# Altium schematic sheet S7CAS-PLEIADES-CARTE-MERE_P01_CNA.SchDoc
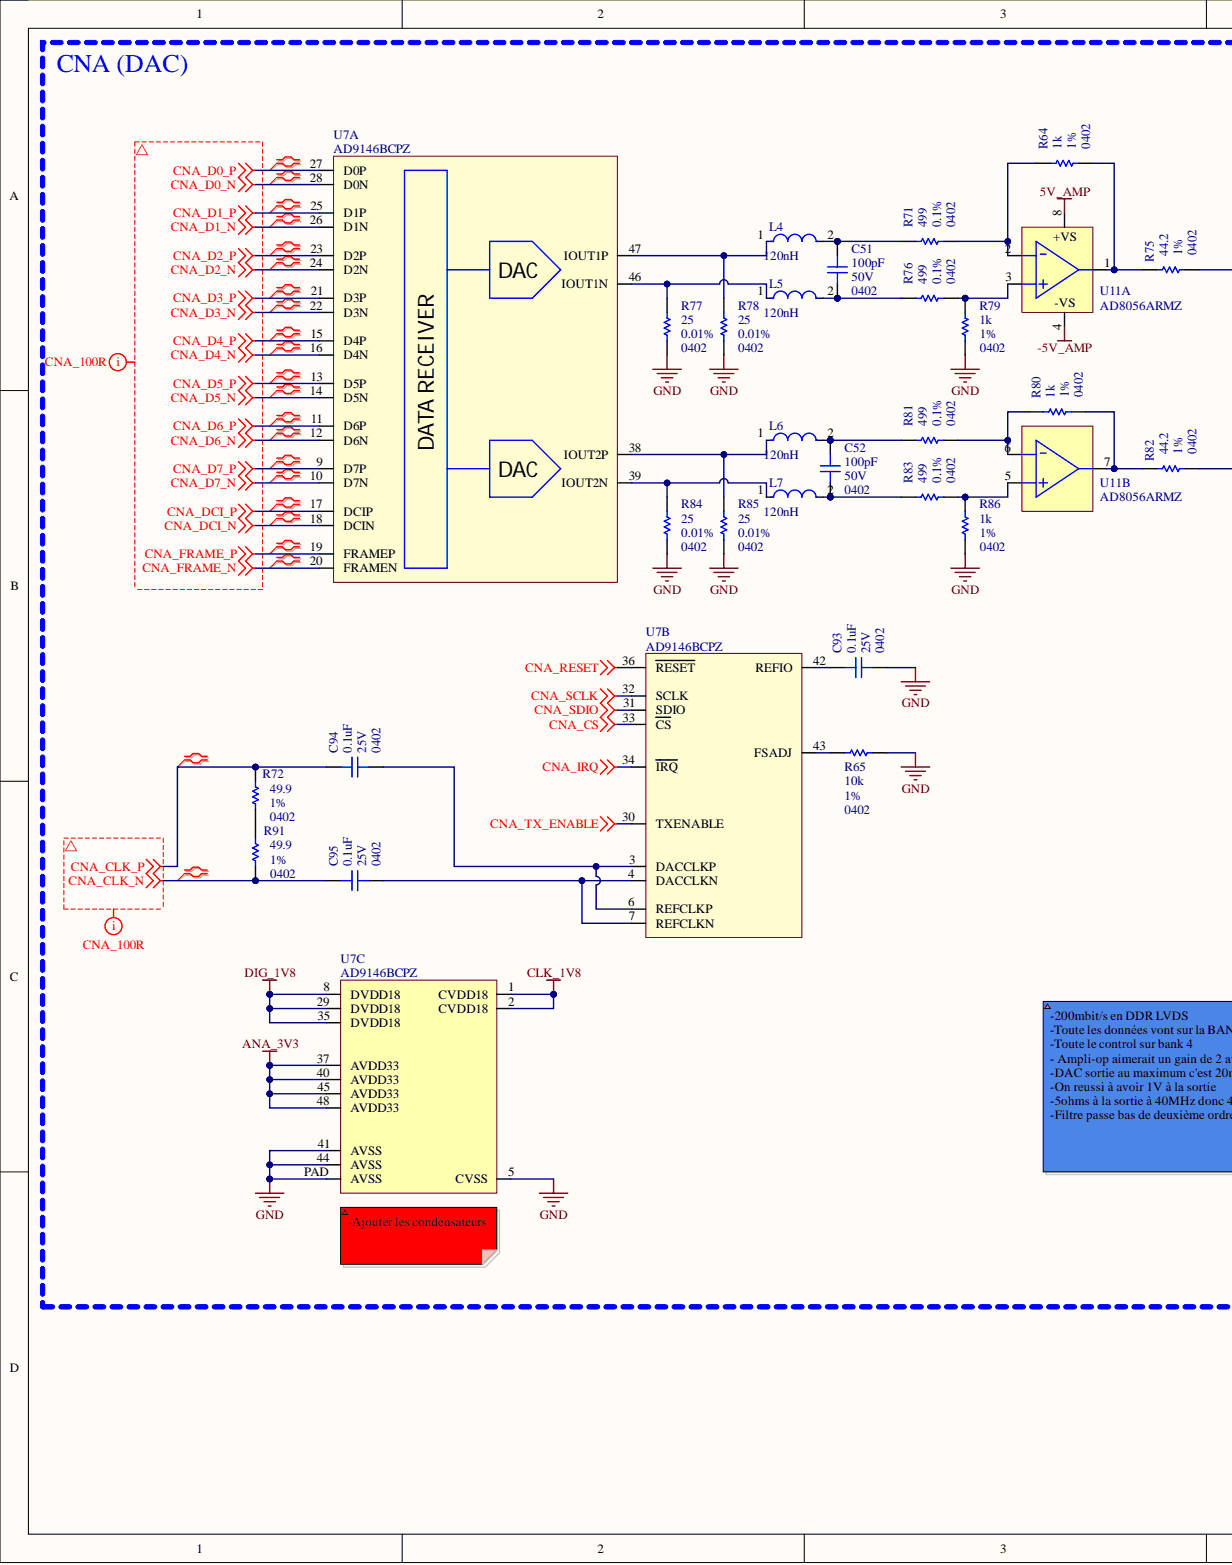
[diagram p0: S7CAS-PLEIADES-CARTE-MERE_P01_CNA.SchDoc, left]
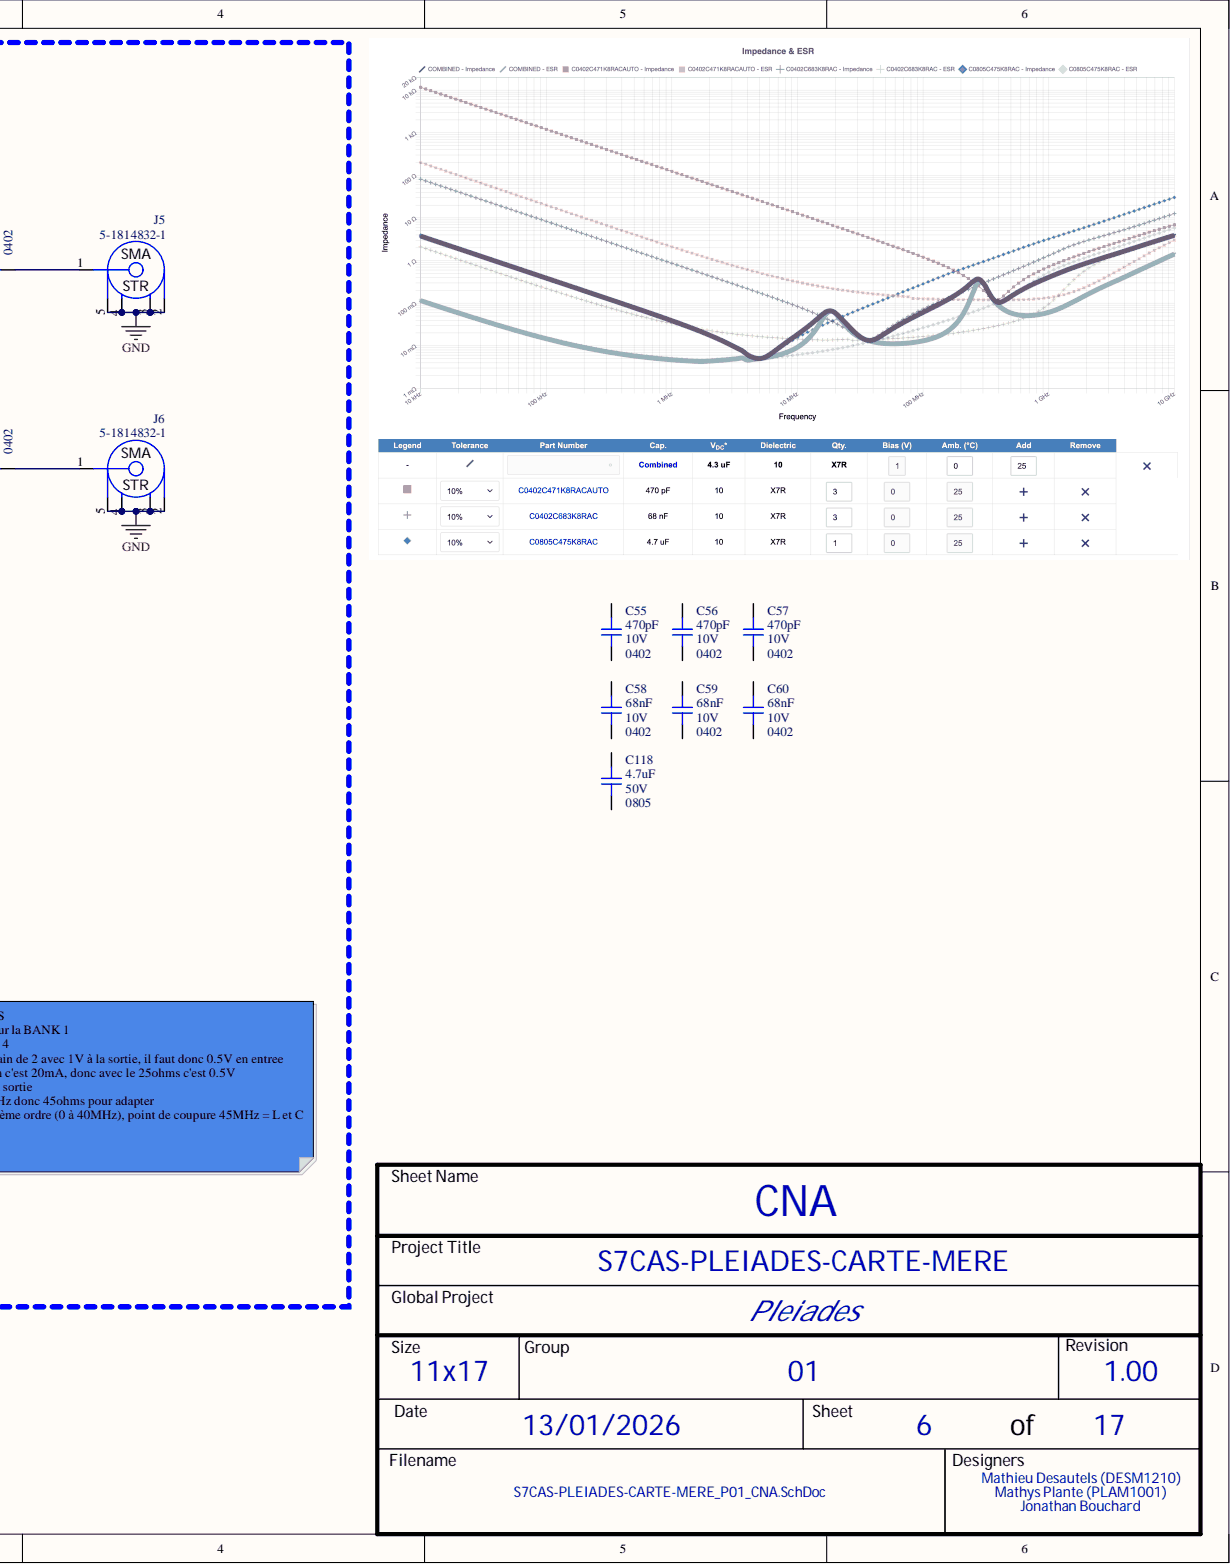
[diagram p1: S7CAS-PLEIADES-CARTE-MERE_P01_CNA.SchDoc, right]

## Parts
C51  value 100pF  Package: 0402  Voltage: 50V  [CAP_0402]  (hidden comment: CAP - 0402)
C52  value 100pF  Package: 0402  Voltage: 50V  [CAP_0402]  (hidden comment: CAP - 0402)
C55  value 470pF  Package: 0402  Voltage: 10V  [CAP_0402]  (hidden comment: CAP - 0402)
C56  value 470pF  Package: 0402  Voltage: 10V  [CAP_0402]  (hidden comment: CAP - 0402)
C57  value 470pF  Package: 0402  Voltage: 10V  [CAP_0402]  (hidden comment: CAP - 0402)
C58  value 68nF  Package: 0402  Voltage: 10V  [CAP_0402]  (hidden comment: CAP - 0402)
C59  value 68nF  Package: 0402  Voltage: 10V  [CAP_0402]  (hidden comment: CAP - 0402)
C60  value 68nF  Package: 0402  Voltage: 10V  [CAP_0402]  (hidden comment: CAP - 0402)
C93  value 0.1uF  Package: 0402  Voltage: 25V  [CAP_0402]  (hidden comment: CAP - 0402)
C94  value 0.1uF  Package: 0402  Voltage: 25V  [CAP_0402]  (hidden comment: CAP - 0402)
C95  value 0.1uF  Package: 0402  Voltage: 25V  [CAP_0402]  (hidden comment: CAP - 0402)
C118  value 4.7uF  Package: 0805  Voltage: 50V  [CAP_0805]  (hidden comment: CAP - 0805)
J5  5-1814832-1  [CONN_SMA_5-1814832-1]
J6  5-1814832-1  [CONN_SMA_5-1814832-1]
L4  Inductance: 120nH  [FP-LQH5BPB_T0-MFG]  (hidden comment: LQH5BPN1R2NT0L)
L5  Inductance: 120nH  [FP-LQH5BPB_T0-MFG]  (hidden comment: LQH5BPN1R2NT0L)
L6  Inductance: 120nH  [FP-LQH5BPB_T0-MFG]  (hidden comment: LQH5BPN1R2NT0L)
L7  Inductance: 120nH  [FP-LQH5BPB_T0-MFG]  (hidden comment: LQH5BPN1R2NT0L)
R64  value 1k  Package: 0402  Precision: 1%  [RES_0402]  (hidden comment: RES - 0402)
R65  value 10k  Precision: 1%  [RES_0402]  (hidden comment: RES - 0402)
R71  value 499  Package: 0402  Precision: 0.1%  [RES_0402]  (hidden comment: RES - 0402)
R72  value 49.9  Precision: 1%  [RES_0402]  (hidden comment: RES - 0402)
R75  value 44.2  Package: 0402  Precision: 1%  [RES_0402]  (hidden comment: RES - 0402)
R76  value 499  Package: 0402  Precision: 0.1%  [RES_0402]  (hidden comment: RES - 0402)
R77  value 25  Package: 0402  [RES_0402]  (hidden comment: RES - 0402)
R78  value 25  Package: 0402  Precision: 0.01%  [RES_0402]  (hidden comment: RES - 0402)
R79  value 1k  Package: 0402  Precision: 1%  [RES_0402]  (hidden comment: RES - 0402)
R80  value 1k  Package: 0402  Precision: 1%  [RES_0402]  (hidden comment: RES - 0402)
R81  value 499  Package: 0402  Precision: 0.1%  [RES_0402]  (hidden comment: RES - 0402)
R82  value 44.2  Package: 0402  Precision: 1%  [RES_0402]  (hidden comment: RES - 0402)
R83  value 499  Package: 0402  Precision: 0.1%  [RES_0402]  (hidden comment: RES - 0402)
R84  value 25  Package: 0402  [RES_0402]  (hidden comment: RES - 0402)
R85  value 25  Package: 0402  Precision: 0.01%  [RES_0402]  (hidden comment: RES - 0402)
R86  value 1k  Package: 0402  Precision: 1%  [RES_0402]  (hidden comment: RES - 0402)
R91  value 49.9  Package: 0402  Precision: 1%  [RES_0402]  (hidden comment: RES - 0402)
U7  AD9146BCPZ  [DAC_AD9146BCPZ]  (parts A,B,C)
U11  AD8056ARMZ  [OPAMP_AD8056ARMZ]  (parts A,B)

## Nets
5V_AMP: U11.8
-5V_AMP: U11.4
ANA_3V3: U7.37(AVDD33) U7.40(AVDD33) U7.45(AVDD33) U7.48(AVDD33)
CLK_1V8: U7.1(CVDD18) U7.2(CVDD18)
CNA_CLK_N: C95.2 R91.1
CNA_CLK_P: C94.2 R72.2
CNA_CS: U7.33(CS)
CNA_D0_N: U7.28(D0N)
CNA_D0_P: U7.27(D0P)
CNA_D1_N: U7.26(D1N)
CNA_D1_P: U7.25(D1P)
CNA_D2_N: U7.24(D2N)
CNA_D2_P: U7.23(D2P)
CNA_D3_N: U7.22(D3N)
CNA_D3_P: U7.21(D3P)
CNA_D4_N: U7.16(D4N)
CNA_D4_P: U7.15(D4P)
CNA_D5_N: U7.14(D5N)
CNA_D5_P: U7.13(D5P)
CNA_D6_N: U7.12(D6N)
CNA_D6_P: U7.11(D6P)
CNA_D7_N: U7.10(D7N)
CNA_D7_P: U7.9(D7P)
CNA_DCI_N: U7.18(DCIN)
CNA_DCI_P: U7.17(DCIP)
CNA_FRAME_N: U7.20(FRAMEN)
CNA_FRAME_P: U7.19(FRAMEP)
CNA_IRQ: U7.34(IRQ)
CNA_RESET: U7.36(RESET)
CNA_SCLK: U7.32(SCLK)
CNA_SDIO: U7.31(SDIO)
CNA_TX_ENABLE: U7.30(TXENABLE)
DIG_1V8: U7.8(DVDD18) U7.29(DVDD18) U7.35(DVDD18)
GND: C93.1 J5.2 J5.3 J5.4 J5.5 J6.2 J6.3 J6.4 J6.5 R65.2 R77.1 R78.1 R79.1 R84.1 R85.1 R86.1 U7.5(CVSS) U7.41(AVSS) U7.44(AVSS) U7.PAD(AVSS)
NetC51_1: C51.1 L5.2 R76.2
NetC51_2: C51.2 L4.2 R71.2
NetC52_1: C52.1 L7.2 R83.2
NetC52_2: C52.2 L6.2 R81.2
NetC93_2: C93.2 U7.42(REFIO)
NetC94_1: C94.1 U7.3(DACCLKP) U7.6(REFCLKP)
NetC95_1: C95.1 U7.4(DACCLKN) U7.7(REFCLKN)
NetJ5_1: J5.1 R75.1
NetJ6_1: J6.1 R82.1
NetL4_1: L4.1 R78.2 U7.47(IOUT1P)
NetL5_1: L5.1 R77.2 U7.46(IOUT1N)
NetL6_1: L6.1 R85.2 U7.38(IOUT2P)
NetL7_1: L7.1 R84.2 U7.39(IOUT2N)
NetR64_1: R64.1 R75.2 U11.1
NetR64_2: R64.2 R71.1 U11.2
NetR65_1: R65.1 U7.43(FSADJ)
NetR72_1: R72.1 R91.2
NetR76_1: R76.1 R79.2 U11.3
NetR80_1: R80.1 R82.2 U11.7
NetR80_2: R80.2 R81.1 U11.6
NetR83_1: R83.1 R86.2 U11.5

Not connected: C55 1 2; C56 1 2; C57 1 2; C58 1 2; C59 1 2; C60 1 2; C118 1 2

## Library data of the parts
C51 C52 = CAP - 0402: 100 pF ±5% 50V Ceramic Capacitor C0G, NP0 0603 (1608 Metric); Mfg Part Number: GRM1885C1H101JA01D; Mfg: Murata Electronics; Component Kind: Standard; Library Name: s7cas_lib2023.intlib; Precision: 5%; CreatedBy: Jonathan Bouchard
C55-C57 = CAP - 0402: Mfg Part Number: C0402C471K8RACAUTO; Precision: 10%; Component Kind: Standard; Description: CAP - 0402; Library Name: s7cas_lib2023.intlib; CreatedBy: Jonathan Bouchard
C58-C60 = CAP - 0402: Mfg Part Number: C0402C683K8RAC; Precision: 10%; Component Kind: Standard; Description: CAP - 0402; Library Name: s7cas_lib2023.intlib; CreatedBy: Jonathan Bouchard
C93-C95 = CAP - 0402: 0.1 µF ±10% 25V Ceramic Capacitor X7R 0402 (1005 Metric); Mfg Part Number: CL05B104KA5NNNC; Mfg: Samsung Electro-Mechanics; Component Kind: Standard; Library Name: s7cas_lib2023.intlib; Part Number #1: CL05B104KA5NNNC; Precision: 10%; CreatedBy: Jonathan Bouchard
C118 = CAP - 0805: 4.7 µF ±10% 50V Ceramic Capacitor X5R 0805 (2012 Metric); Mfg Part Number: GRT21BR61H475KE13L; Mfg: Murata Electronics; Component Kind: Standard; Library Name: s7cas_lib2023.intlib; Precision: 10%; CreatedBy: Jonathan Bouchard
J5 J6 = CONN_SMA_5-1814832-1: CONN -  SMA JACK STR 50 OHM - TH; Mfg: TE Connectivity AMP Connectors; Component Kind: Standard; HelpURL: http://www.te.com/commerce/DocumentDelivery/DDEController?Action=srchrtrv&DocNm=1814832&DocType=Customer+Drawing&DocLang=English; Library Name: s7cas_lib2023.intlib; Mfg Part Number: 5-1814832-1; Package: TH-5; Supplier 1: Digikey; Supplier Part Number 1: A97594-ND; Temperature: -65°C ~ 165°C; CreatedBy: Jonathan Bouchard
L4-L7 = CMP-06042-001298-1: Wire Wound Ferrite Inductor for Power Lines 1.2μH ±30% 0.0228Ω 3.1A 2020; DC Resistance (DCR): 19mR; Saturation Current: 5.4A; Self Resonant Frequency: 90MHz; Shielding: Shielded; Test Frequency: 100kHz; Component Kind: Standard; Current Rating: 3.1A; Height: 2mm; Height - Seated (Max): 0.086inch; Inductance Tolerance: 30%; Length: 0.197inch; Library Name: Altium Content Vault; Max DC Current: 3.1A; Max Operating Temperature: 85°C; Package Quantity: 500; Packaging: Tape and Reel; REACH SVHC: No SVHC; Resistor Type: Wirewound; Termination: SMD/SMT; Tolerance: 30%; Width: 5mm
R64 R79 R80 R86 = RES - 0402: 1 kOhms ±1% 0.1W, 1/10W Chip Resistor 0402 (1005 Metric) Automotive AEC-Q200 Thick Film; Mfg Part Number: ERJ-2RKF1001X; Mfg: Panasonic Electronic Components; Voltage: 50V; Component Kind: Standard; Library Name: s7cas_lib2023.intlib; Part Number #1: ERJ-2RKF1001X; Power: 1/10W; CreatedBy: Jonathan Bouchard
R65 = RES - 0402: 10 kOhms ±1% 0.063W, 1/16W Chip Resistor 0402 (1005 Metric) Automotive AEC-Q200 Thick Film; Mfg Part Number: RMCF0402FT10K0; Voltage: 75V; Component Kind: Standard; Library Name: s7cas_lib2023.intlib; Package: 0402; Part Number #1: RMCF0402FT10K0; Power: 1/16W; CreatedBy: Jonathan Bouchard
R71 R76 R81 R83 = RES - 0402: 499 Ohms ±0.1% 0.063W, 1/16W Chip Resistor 0402 (1005 Metric) Automotive AEC-Q200 Thin Film; Mfg Part Number: ERA-2AEB4990X; Mfg: Panasonic Electronic Components; Voltage: TBD; Component Kind: Standard; Library Name: s7cas_lib2023.intlib; Power: 1/16W; CreatedBy: Jonathan Bouchard
R72 R91 = RES - 0402: 49.9 Ohms ±1% 0.1W, 1/10W Chip Resistor 0402 (1005 Metric) Automotive AEC-Q200 Thick Film; Mfg Part Number: CRCW040249R9FKED; Mfg: Vishay Dale; Voltage: 75V; Component Kind: Standard; Library Name: s7cas_lib2023.intlib; Part Number #1: CRCW040249R9FKED; Power: 1/10W; CreatedBy: Jonathan Bouchard
  R72: Package: 0402
R75 R82 = RES - 0402: 44.2 Ohms ±1% 0.1W, 1/10W Chip Resistor 0402 (1005 Metric) Automotive AEC-Q200 Thick Film; Mfg Part Number: ERJ-2RKF44R2X; Mfg: Panasonic Electronic Components; Voltage: TBD; Component Kind: Standard; Library Name: s7cas_lib2023.intlib; Power: 1/10W; CreatedBy: Jonathan Bouchard
R77 R84 = RES - 0402: 25 Ohms ±0.1% 0.05W, 1/20W Chip Resistor 0402 (1005 Metric) RF, High Frequency Thin Film; Mfg Part Number: FC0402E25R0BTT0; Mfg: Vishay Dale Thin Film; Precision: 0.01%; Voltage: TBD; Component Kind: Standard; Library Name: s7cas_lib2023.intlib; Power: 1/20W; CreatedBy: Jonathan Bouchard
R78 R85 = RES - 0402: 25 Ohms ±0.1% 0.05W, 1/20W Chip Resistor 0402 (1005 Metric) RF, High Frequency Thin Film; Mfg Part Number: FC0402E25R0BTT0; Mfg: Vishay Dale Thin Film; Voltage: TBD; Component Kind: Standard; Library Name: s7cas_lib2023.intlib; Power: 1/20W; CreatedBy: Jonathan Bouchard
U7 = DAC_AD9146BCPZ: DAC - 16BIT SRL HIGH SPEED - QFN-48; Mfg: Analog Devices Inc.; Voltage: 3.13 V ~ 3.47 V / 1.71 V ~ 1.89 V; Component Kind: Standard; HelpURL: http://www.analog.com/media/en/technical-documentation/data-sheets/AD9146.PDF; Library Name: s7cas_lib2023.intlib; Mfg Part Number: AD9146BCPZ; Package: QFN-48; Precision: 16 Bit; Supplier 1: Digikey; Supplier Part Number 1: AD9146BCPZ-ND; Temperature: -40°C ~ 85°C; CreatedBy: Jonathan Bouchard
U11 = OPAMP_AD8056ARMZ: OPAMP - VFB 300MHZ - 8MSOP; Current: 60mA; Mfg: Analog Devices; Voltage: 8 V ~ 12 V, ±4 V ~ 6 V; Component Kind: Standard; HelpURL: http://www.analog.com/media/en/technical-documentation/data-sheets/AD8055_8056.pdf; Library Name: s7cas_lib2023.intlib; Mfg Part Number: AD8056ARMZ; Package: MSOP-8; Supplier 1: Digikey; Supplier Part Number 1: AD8056ARMZ-REEL7CT-ND; Temperature: -40°C ~ 125°C; CreatedBy: Jonathan Bouchard
